# Altium schematic sheet motor_controller_relays.SchDoc
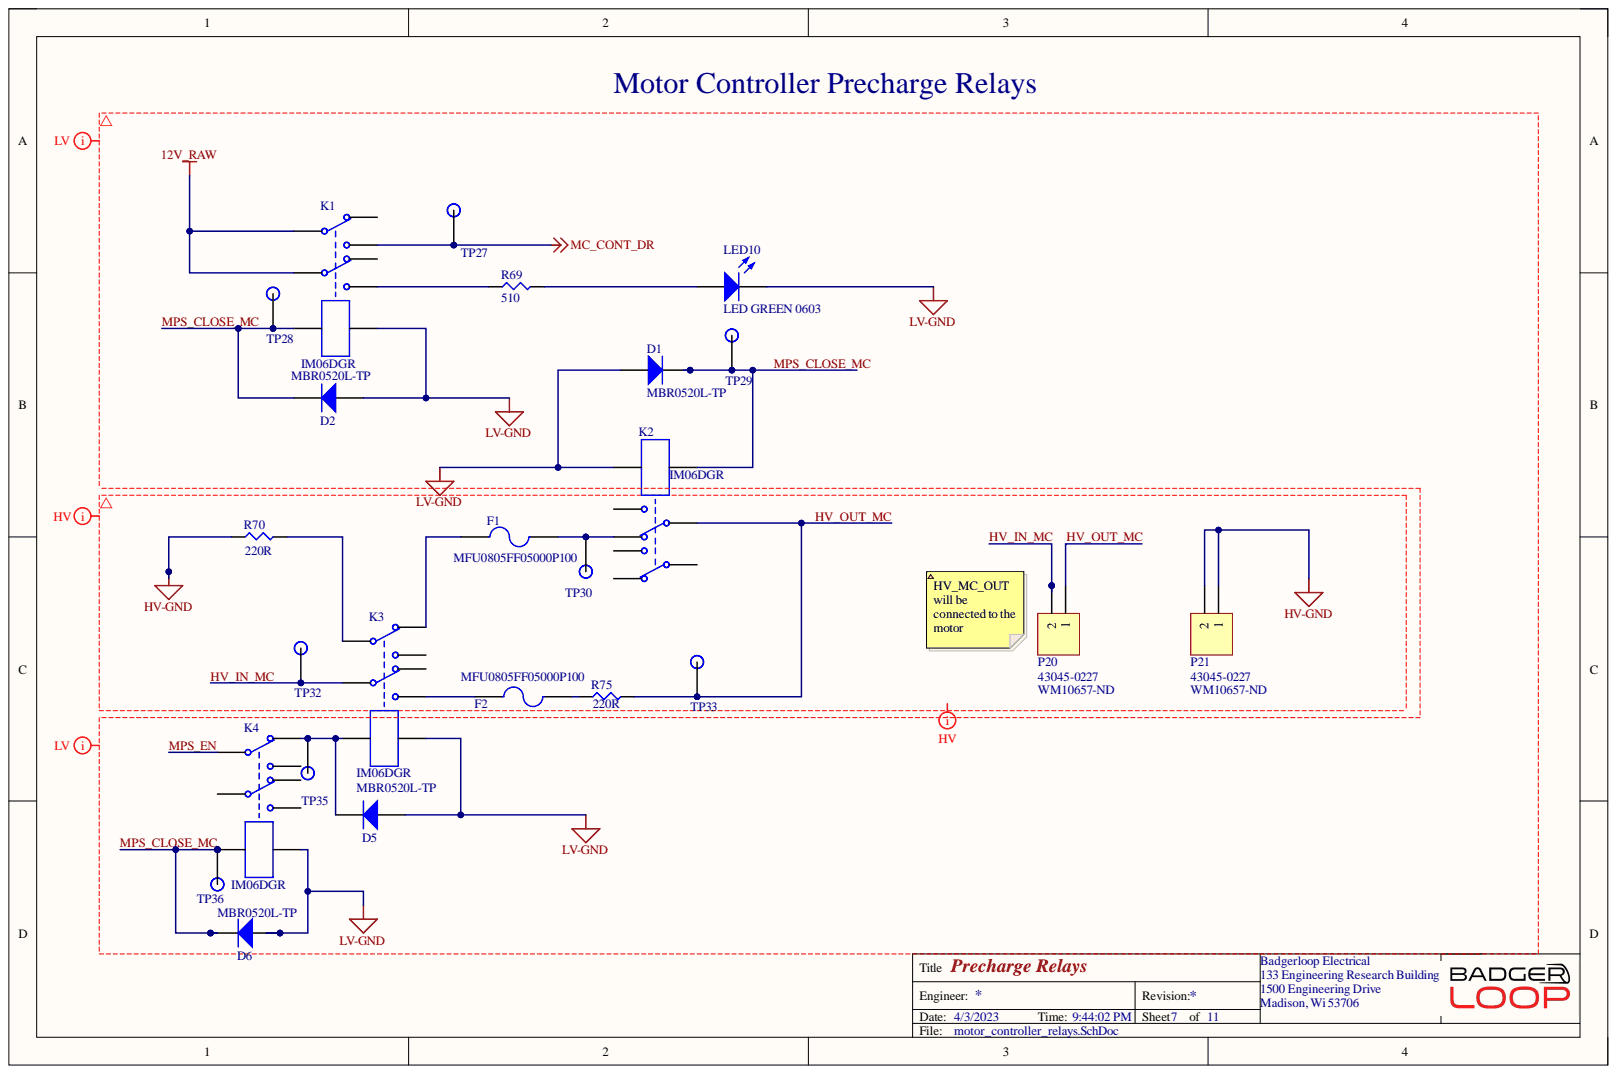
[diagram p0: motor_controller_relays.SchDoc]

## Parts
D1  MBR0520L-TP  [MBR0520LT1G - SOD123]
D2  MBR0520L-TP  [MBR0520LT1G - SOD123]
D5  MBR0520L-TP  [MBR0520LT1G - SOD123]
D6  MBR0520L-TP  [MBR0520LT1G - SOD123]
F1  MFU0805FF05000P100  [SMD-0805-RES]
F2  MFU0805FF05000P100  [SMD-0805-RES]
K1  Manufacturer Part Number: IM06DGR  [1-1462039-8 - relay]  (hidden comment: 1-1462039-8)
K2  Manufacturer Part Number: IM06DGR  [1-1462039-8 - relay]  (hidden comment: 1-1462039-8)
K3  Manufacturer Part Number: IM06DGR  [1-1462039-8 - relay]  (hidden comment: 1-1462039-8)
K4  Manufacturer Part Number: IM06DGR  [1-1462039-8 - relay]  (hidden comment: 1-1462039-8)
LED10  LED GREEN 0603  Color: GREEN  [LED 0603 GREEN]
P20  43045-0227  [43045-0277]
P21  43045-0227  [43045-0277]
R69  RMCF1206FT510R  [SMD-1206-RES]  (value not drawn)
R70  Resistance: 220R  [TO-247-2]  (hidden comment: AP101220RJ)
R75  Resistance: 220R  [TO-247-2]  (hidden comment: AP101220RJ)
TP27  TP  [Test Point]
TP28  TP  [Test Point]
TP29  TP  [Test Point]
TP30  TP  [Test Point]
TP32  TP  [Test Point]
TP33  TP  [Test Point]
TP35  TP  [Test Point]
TP36  TP  [Test Point]

## Nets
12V_RAW: K1.3 K1.6
HV-GND: P21.1 P21.2 R70.1
LV-GND: D1.1 D2.1 D5.1 D6.1 K1.8 K2.8 K3.8 K4.8 LED10.2
MC_CONT_DR: K1.4 TP27.1
HV_IN_MC: K3.6 P20.2 TP32.1
HV_OUT_MC: K2.6 P20.1 R75.2 TP33.1
MPS_CLOSE_MC: D1.2 D2.2 D6.2 K1.1 K2.1 K4.1 TP28.1 TP29.1 TP36.1
MPS_EN: K4.3
NetD5_2: D5.2 K3.1 K4.2 TP35.1
NetF1_1: F1.1 K3.2
NetF1_2: F1.2 K2.7 TP30.1
NetF2_1: F2.1 K3.5
NetF2_2: F2.2 R75.1
NetK1_5: K1.5 R69.1
NetK3_3: K3.3 R70.2
NetLED10_1: LED10.1 R69.2

Not connected: K1 2 7; K2 2 3 4 5; K3 4 7; K4 4 5 6 7

## Library data of the parts
D1 D2 D5 D6 = MBR0520L-TP: Rectifier Diode, Schottky, 1 Phase, 1 Element, 0.5A, 20V V(RRM), Silicon; Manufacturer: MCC; Forward Voltage-Max (VF): 300mV; Max Output Current: 500mA; Max Power Dissipation: 410mW; Non-rep Pk Forward Current-Max: 5.5A; Number of Elements: 1; Package Body Material: Plastic; Qualification Status: Not Qualified; Time @ Peak Reflow Temperature-Max (s): 10s; Category: Rectifier Diodes; Component Kind: Standard; ComponentLink1Description: https://datasheet.ciiva.com:443/pdfs/VipMasterIC/IC/MCCC/MCCC-S-A0007607609/MCCC-S-A0007607609-1.pdf?src-supplier=IHS+Markit; ComponentLink1URL: https://datasheet.ciiva.com:443/pdfs/VipMasterIC/IC/MCCC/MCCC-S-A0007607609/MCCC-S-A0007607609-1.pdf?src-supplier=IHS+Markit; ComponentLink2Description: https://datasheet.ciiva.com/24106/mbr0520lmbr0540lsod-123-276512-24106653.pdf?src-supplier=Mouser; ComponentLink2URL: https://datasheet.ciiva.com/24106/mbr0520lmbr0540lsod-123-276512-24106653.pdf?src-supplier=Mouser; ComponentLink3Description: https://datasheet.ciiva.com/6381/mbr0520lmbr0540lsod-123-6381109.pdf?src-supplier=Digi-Key; ComponentLink3URL: https://datasheet.ciiva.com/6381/mbr0520lmbr0540lsod-123-6381109.pdf?src-supplier=Digi-Key; Diode Element Material: SILICON; Diode Type: RECTIFIER; JESD-30 Code: R-PDSO-G2; JESD-609 Code: e3; Library Name: misc.SchLib; Manufacturer Part Number: MBR0520L-TP; Max Operating Temperature: 125°C; Moisture Sensitivity Level: 1; Number of Pins: 2; Package Shape: Rectangular; Package Style: SMALL OUTLINEMeter; Peak Reflow Temperature (Cel): 260°C; Pricing 1: 1=0.52000, 10=0.38700, 100=0.21930, 500=0.14520, 1000=0.11132 (USD); REACH SVHC: Yes; Rep Pk Reverse Voltage-Max: 20V; RoHS: Yes; RoHS Compliant: Yes; Stock 1: 75810; Supplier 1: Digi-Key; Supplier Part Number 1: MBR0520L-TPMSCT-ND; Surface Mount: Yes; Technology: SCHOTTKY; Terminal Finish: Tin; Terminal Form: Gull Wing; Terminal Position: DUAL
F1 F2 = MFU0805FF05000P100: Electric Fuse, Very Fast Blow, 5A, 32VDC, 50A (IR), Surface Mount; Manufacturer: Vishay; Body Breadth: 1.25inch; Body Height: 0.45mm; Body Length or Diameter: 2mm; Joule-integral-Nom: 0.2275J; Reference Standard: UL; Voltage Rating (DC): 32V; Blow Characteristic: VERY FAST; Category: Fuses; Circuit Protection Type: Electric Fuse; Component Kind: Standard; ComponentLink1Description: https://datasheet.ciiva.com:443/pdfs/VipMasterIC/IC/VISH/VISH-S-A0001809179/VISH-S-A0001809179-1.pdf?src-supplier=IHS+Markit; ComponentLink1URL: https://datasheet.ciiva.com:443/pdfs/VipMasterIC/IC/VISH/VISH-S-A0001809179/VISH-S-A0001809179-1.pdf?src-supplier=IHS+Markit; ComponentLink2Description: https://datasheet.ciiva.com/1730/mfuserie-1730720.pdf?src-supplier=Newark; ComponentLink2URL: https://datasheet.ciiva.com/1730/mfuserie-1730720.pdf?src-supplier=Newark; ComponentLink3Description: https://datasheet.ciiva.com/11337/mfuserie-11337721.pdf?src-supplier=Avnet; ComponentLink3URL: https://datasheet.ciiva.com/11337/mfuserie-11337721.pdf?src-supplier=Avnet; ComponentLink4Description: https://datasheet.ciiva.com/8057/1480005-8057917.pdf?src-supplier=Element14; ComponentLink4URL: https://datasheet.ciiva.com/8057/1480005-8057917.pdf?src-supplier=Element14; ComponentLink5Description: https://datasheet.ciiva.com/22734/1480005-22734093.pdf?src-supplier=Element14; ComponentLink5URL: https://datasheet.ciiva.com/22734/1480005-22734093.pdf?src-supplier=Element14; ComponentLink6Description: https://datasheet.ciiva.com/17414/mfuserie-17414775.pdf?src-supplier=Avnet+Europe; ComponentLink6URL: https://datasheet.ciiva.com/17414/mfuserie-17414775.pdf?src-supplier=Avnet+Europe; ComponentLink7Description: https://datasheet.ciiva.com/1730/mfuserie-1730721.pdf?src-supplier=Newark; ComponentLink7URL: https://datasheet.ciiva.com/1730/mfuserie-1730721.pdf?src-supplier=Newark; Current Rating: 5A; JESD-609 Code: e3; Library Name: misc.SchLib; Manufacturer Part Number: MFU0805FF05000P100; Max Operating Temperature: 35°C; Min Operating Temperature: 15°C; Mount: Surface Mount; Packaging: Tape and Reel; Pricing 1: 1=0.49000, 5=0.47600, 10=0.46200, 50=0.38880, 100=0.32270, 250=0.29340, 500=0.24940 (USD); Rated Breaking Capacity: 50A; REACH SVHC: Yes; RoHS: Yes; RoHS Compliant: Yes; Stock 1: 50547; Supplier 1: Digi-Key; Supplier Part Number 1: MFU08055.00CT-ND; Terminal Finish: Tin; Termination: Wraparound
K1-K4 = 1-1462039-8: Electromechanical Relay, DPDT, 140mW (Coil), 5A (Contact), 220VDC (Contact), Random, AC/DC Output; Manufacturer: TE Connectivity; Body Breadth: 6inch; Body Height: 5.65mm; Body Length or Diameter: 10mm; Coil Operate Voltage(DC): 9V; Coil Release Voltage(DC): 1.2V; Coil Resistance: 1.029kR; Coil Voltage-Nom: 12V; Coil/Input Supply Type: DC; Contact AC Max Power Rating R Load: 62.5VA@250VAC; Contact AC Max Rating R Load: 5A@250VAC; Contact DC Max Power Rating R Load: 60W@220VDC; Contact DC Max Rating R Load: 5A@220VDC; Contact Resistance: 50mR; Contact Voltage(AC)-Max: 250V; Contact Voltage(DC)-Max: 220V; Dielectric Strength Between Coil and Contacts: 1500Vrms; Dielectric Strength Between Open Contacts: 750Vrms; End Contact Material: Silver; End Contact Plating: Gold; Insulation Resistance: 1GR; Operate Time: 3ms; Reference Standard: UL; Relay Form: 2 FORM C; Sealing: HERMETICAL; Category: Power Relays; Coil Power: 140mW; Component Kind: Standard; ComponentLink1Description: https://datasheet.ciiva.com:443/pdfs/VipMasterIC/IC/TYEL/TYELS75403/TYELS75403-1.pdf?src-supplier=IHS+Markit; ComponentLink1URL: https://datasheet.ciiva.com:443/pdfs/VipMasterIC/IC/TYEL/TYELS75403/TYELS75403-1.pdf?src-supplier=IHS+Markit; ComponentLink2Description: https://datasheet.ciiva.com/1662/13778-1662593.pdf?src-supplier=Newark; ComponentLink2URL: https://datasheet.ciiva.com/1662/13778-1662593.pdf?src-supplier=Newark; ComponentLink3Description: https://datasheet.ciiva.com/1525/1717896-1525047.pdf?src-supplier=Element14; ComponentLink3URL: https://datasheet.ciiva.com/1525/1717896-1525047.pdf?src-supplier=Element14; ComponentLink4Description: https://datasheet.ciiva.com/1612/1717896-1612285.pdf?src-supplier=Farnell; ComponentLink4URL: https://datasheet.ciiva.com/1612/1717896-1612285.pdf?src-supplier=Farnell; ComponentLink5Description: https://datasheet.ciiva.com/30159/customerdrawing1462037-4a10-30159339.pdf?src-supplier=Onlinecomponents.com; ComponentLink5URL: https://datasheet.ciiva.com/30159/customerdrawing1462037-4a10-30159339.pdf?src-supplier=Onlinecomponents.com; ComponentLink6Description: https://datasheet.ciiva.com/22697/1717896-22697038.pdf?src-supplier=Element14; ComponentLink6URL: https://datasheet.ciiva.com/22697/1717896-22697038.pdf?src-supplier=Element14; Contact Current(AC)-Max: 5A; Contact Current(DC)-Max: 5A; Contact/Output Supply Type: AC/DC; Input Switching Control Type: Random; Library Name: misc.SchLib; Number of Pins: 8; Packaging: Tape and Reel; Pricing 1: 1=5.59000, 10=5.24500, 25=4.66200, 50=4.42880, 100=4.19580, 250=3.72960, 500=3.49650 (USD); REACH SVHC: No SVHC; Relay Function: DPDT; RoHS: No; RoHS Compliant: No; Stock 1: 35148; Supplier 1: Digi-Key; Supplier Part Number 1: PB1174CT-ND; Termination: Solder; Weight: 0.75g
LED10 = LED GREEN 0603: Manufacturer: Lite-On Inc.; Manufacturer P/N: LTST-C190KGKT; Component Kind: Standard; Digikey P/N: 160-1435-1-ND; Library Name: misc.SchLib; Package / Case: 0603
P20 P21 = 43045-0227: Board Connector, 2 Contact(s), 2 Row(s), Male, Straight, Solder Terminal, Locking, Black Insulator, Receptacle; Manufacturer: Molex; Board Mounting Option: PEG; Body Breadth: 0.29inch; Body Depth: 0.39inch; Body Length: 0.262inch; Contact Resistance: 10mR; Contact Style: SQ PIN-SKT; DIN Conformance: No; Insertion Force-Max: 8.0064N; Insulation Resistance: 1GR; Mating Information: MULTIPLE MATING PARTS AVAILABLE; Number Of Connectors: 1; Number of Contacts: 2; Option: General Purpose; Plating Thickness: 6uin; Rated Current (Signal): 2.5A; Reference Standard: UL; Reliability: COMMERCIAL; Throw Configuration: S0P2; Withdrawl Force-Min: 3.5584N; Body/Shell Style: RECEPTACLE; Category: Headers and Wire Housings; Component Kind: Standard; ComponentLink1Description: https://datasheet.ciiva.com:443/pdfs/VipMasterIC/IC/MOLE/MOLE-S-A0001360181/MOLE-S-A0001399497-1.pdf?src-supplier=IHS+Markit; ComponentLink1URL: https://datasheet.ciiva.com:443/pdfs/VipMasterIC/IC/MOLE/MOLE-S-A0001360181/MOLE-S-A0001399497-1.pdf?src-supplier=IHS+Markit; ComponentLink2Description: https://datasheet.ciiva.com/5148/0430450227-pcb-headers-142611-5148694.pdf?src-supplier=Mouser; ComponentLink2URL: https://datasheet.ciiva.com/5148/0430450227-pcb-headers-142611-5148694.pdf?src-supplier=Mouser; ComponentLink3Description: https://datasheet.ciiva.com/16103/307170430450227-pcb-headers-16103122.pdf?src-supplier=Onlinecomponents.com; ComponentLink3URL: https://datasheet.ciiva.com/16103/307170430450227-pcb-headers-16103122.pdf?src-supplier=Onlinecomponents.com; ComponentLink4Description: https://datasheet.ciiva.com/11752/0900766b80d4a80b-11752890.pdf?src-supplier=RSComponents; ComponentLink4URL: https://datasheet.ciiva.com/11752/0900766b80d4a80b-11752890.pdf?src-supplier=RSComponents; ComponentLink5Description: https://datasheet.ciiva.com/30256/212010430450227-pcb-headers-30256881.pdf?src-supplier=Onlinecomponents.com; ComponentLink5URL: https://datasheet.ciiva.com/30256/212010430450227-pcb-headers-30256881.pdf?src-supplier=Onlinecomponents.com; ComponentLink6Description: https://datasheet.ciiva.com/16725/0430450227-pcb-headers-16725483.pdf?src-supplier=Avnet+Europe; ComponentLink6URL: https://datasheet.ciiva.com/16725/0430450227-pcb-headers-16725483.pdf?src-supplier=Avnet+Europe; ComponentLink7Description: https://datasheet.ciiva.com/10089/0430450227-pcb-headers-10089453.pdf?src-supplier=Avnet; ComponentLink7URL: https://datasheet.ciiva.com/10089/0430450227-pcb-headers-10089453.pdf?src-supplier=Avnet; Connector Type: BOARD CONNECTOR; Contact Finish - Mating: TIN (100) OVER NICKEL; Contact Finish - Termination: TIN (100) OVER NICKEL; Contact Gender: Male; Contact Material: Brass; Filter Feature: No; Flammability Rating: UL94 V-0; IEC Conformance: No; Insulation Color: Black; Insulation Material: Polymer; JESD-609 Code: e3; Library Name: connector.SchLib; Manufacturer Part Number: 43045-0227; Max Operating Temperature: 105°C; MIL Conformance: No; Min Operating Temperature: -40°C; Mixed Contacts: No; Mount: Board; Mounting Option 1: LOCKING; Mounting Style: STRAIGHT; Number of Rows Loaded: 2; Pricing 1: 1=0.97000, 10=0.80600, 80=0.67200, 945=0.53760, 2400=0.48720 (USD); REACH SVHC: Yes; RoHS: Yes; RoHS Compliant: Yes; Stock 1: 12970; Supplier 1: Digi-Key; Supplier Part Number 1: WM10657-ND; Terminal Length: 0.125inch; Terminal Pitch: 3mm; Termination: Solder
R69 = RMCF1206FT510R: Fixed Resistor, Metal Glaze/thick Film, 0.25W, 510ohm, 200V, 1% +/-Tol, 100ppm/Cel, Surface Mount, 1206; Manufacturer: Stackpole Electronics; Package Height: 0.55mm; Package Length: 3.2mm; Package Width: 1.6mm; Rated Power Dissipation (P): 250mW; Rated Temperature: 70°C; Reference Standard: AEC-Q200; Resistance: 510; Temperature Coefficient: 100ppm/°C; Category: Chip SMD Resistors; Component Kind: Standard; ComponentLink1Description: https://datasheet.ciiva.com:443/pdfs/VipMasterIC/IC/SEIE/SEIE-S-A0009541969/SEIE-S-A0009541969-1.pdf?src-supplier=IHS+Markit; ComponentLink1URL: https://datasheet.ciiva.com:443/pdfs/VipMasterIC/IC/SEIE/SEIE-S-A0009541969/SEIE-S-A0009541969-1.pdf?src-supplier=IHS+Markit; ComponentLink2Description: http://components.arrow.com/part/dataview/40948710S9551678N1174?src-supplier=Arrow+Electronics; ComponentLink2URL: http://components.arrow.com/part/dataview/40948710S9551678N1174?src-supplier=Arrow+Electronics; ComponentLink3Description: https://www.seielect.com/Catalog/SEI-RMCF_RMCP.pdf?src-supplier=Digi-Key; ComponentLink3URL: https://www.seielect.com/Catalog/SEI-RMCF_RMCP.pdf?src-supplier=Digi-Key; ComponentLink4Description: https://www.seielect.com/catalog/SEI-Packaging.pdf?src-supplier=Digi-Key; ComponentLink4URL: https://www.seielect.com/catalog/SEI-Packaging.pdf?src-supplier=Digi-Key; ComponentLink5Description: https://www.seielect.com/catalog/SEI-RMCF_RMCP.pdf?src-supplier=Avnet; ComponentLink5URL: https://www.seielect.com/catalog/SEI-RMCF_RMCP.pdf?src-supplier=Avnet; Construction: Rectangular; JESD-609 Code: e3; Library Name: resistors.SchLib; Manufacturer Part Number: RMCF1206FT510R; Max Operating Temperature: 155°C; Min Operating Temperature: -55°C; Mount: Surface Mount; Number of Pins: 2; Package Shape: Rectangular; Package Style: SMTMeter; Packaging: Tape and Reel; Pricing 1: 1=0.10000, 10=0.03600, 100=0.01460, 1000=0.00655, 2500=0.00569 (USD); REACH SVHC: Yes; Resistor Type: FIXED RESISTOR; RoHS: Yes; RoHS Compliant: Yes; Size Code: 1206; Stock 1: 51; Supplier 1: Digi-Key; Supplier Part Number 1: RMCF1206FT510RCT-ND; Technology: METAL GLAZE/THICK FILM; Terminal Finish: Nickel; Termination: Wraparound; Tolerance: 1%; Working Voltage: 200V
R70 R75 = AP101220RJ: Fixed Resistor, 100W, 220ohm, 700V, 5% +/-Tol, 100ppm/Cel, Through Hole Mount; Manufacturer: Ohmite; Rated Power Dissipation (P): 3.5W; Rated Temperature: 25°C; Temperature Coefficient: 100ppm/°C; Category: Through-Hole Resistors; Component Kind: Standard; ComponentLink1Description: https://datasheet.ciiva.com:443/pdfs/VipMasterIC/IC/OMIT/OMIT-S-A0001298920/OMIT-S-A0001298920-1.pdf?src-supplier=IHS+Markit; ComponentLink1URL: https://datasheet.ciiva.com:443/pdfs/VipMasterIC/IC/OMIT/OMIT-S-A0001298920/OMIT-S-A0001298920-1.pdf?src-supplier=IHS+Markit; ComponentLink2Description: http://www.farnell.com/datasheets/2015590.pdf?src-supplier=Farnell; ComponentLink2URL: http://www.farnell.com/datasheets/2015590.pdf?src-supplier=Farnell; ComponentLink3Description: http://www.mouser.com/ds/2/303/acl_ap101-773331.pdf?src-supplier=Mouser; ComponentLink3URL: http://www.mouser.com/ds/2/303/acl_ap101-773331.pdf?src-supplier=Mouser; ComponentLink4Description: http://www.ohmite.com/cat/acl_ap101.pdf?src-supplier=Digi-Key; ComponentLink4URL: http://www.ohmite.com/cat/acl_ap101.pdf?src-supplier=Digi-Key; Library Name: resistors.SchLib; Manufacturer Part Number: AP101220RJ; Max Operating Temperature: 175°C; Min Operating Temperature: -65°C; Mount: Through Hole; Number of Pins: 2; Package Shape: Rectangular; Pricing 1: 1=6.63000, 10=6.21800, 35=5.80343, 70=5.11271, 105=4.42171, 245=4.00722, 455=3.73086, 945=3.67501 (USD); REACH SVHC: Yes; Resistor Type: FIXED RESISTOR; RoHS: Yes; RoHS Compliant: Yes; Stock 1: 44; Supplier 1: Digi-Key; Supplier Part Number 1: AP101220RJ-ND; Termination: Flat; Tolerance: 5%; Working Voltage: 700V
TP27-TP30 TP32 TP33 TP35 TP36 = TP: SMD TEST POINT; Component Kind: Standard; Library Name: misc.SchLib
